# Altium schematic sheet 11-POWER-P12V.SchDoc(11-POWER-P12V)
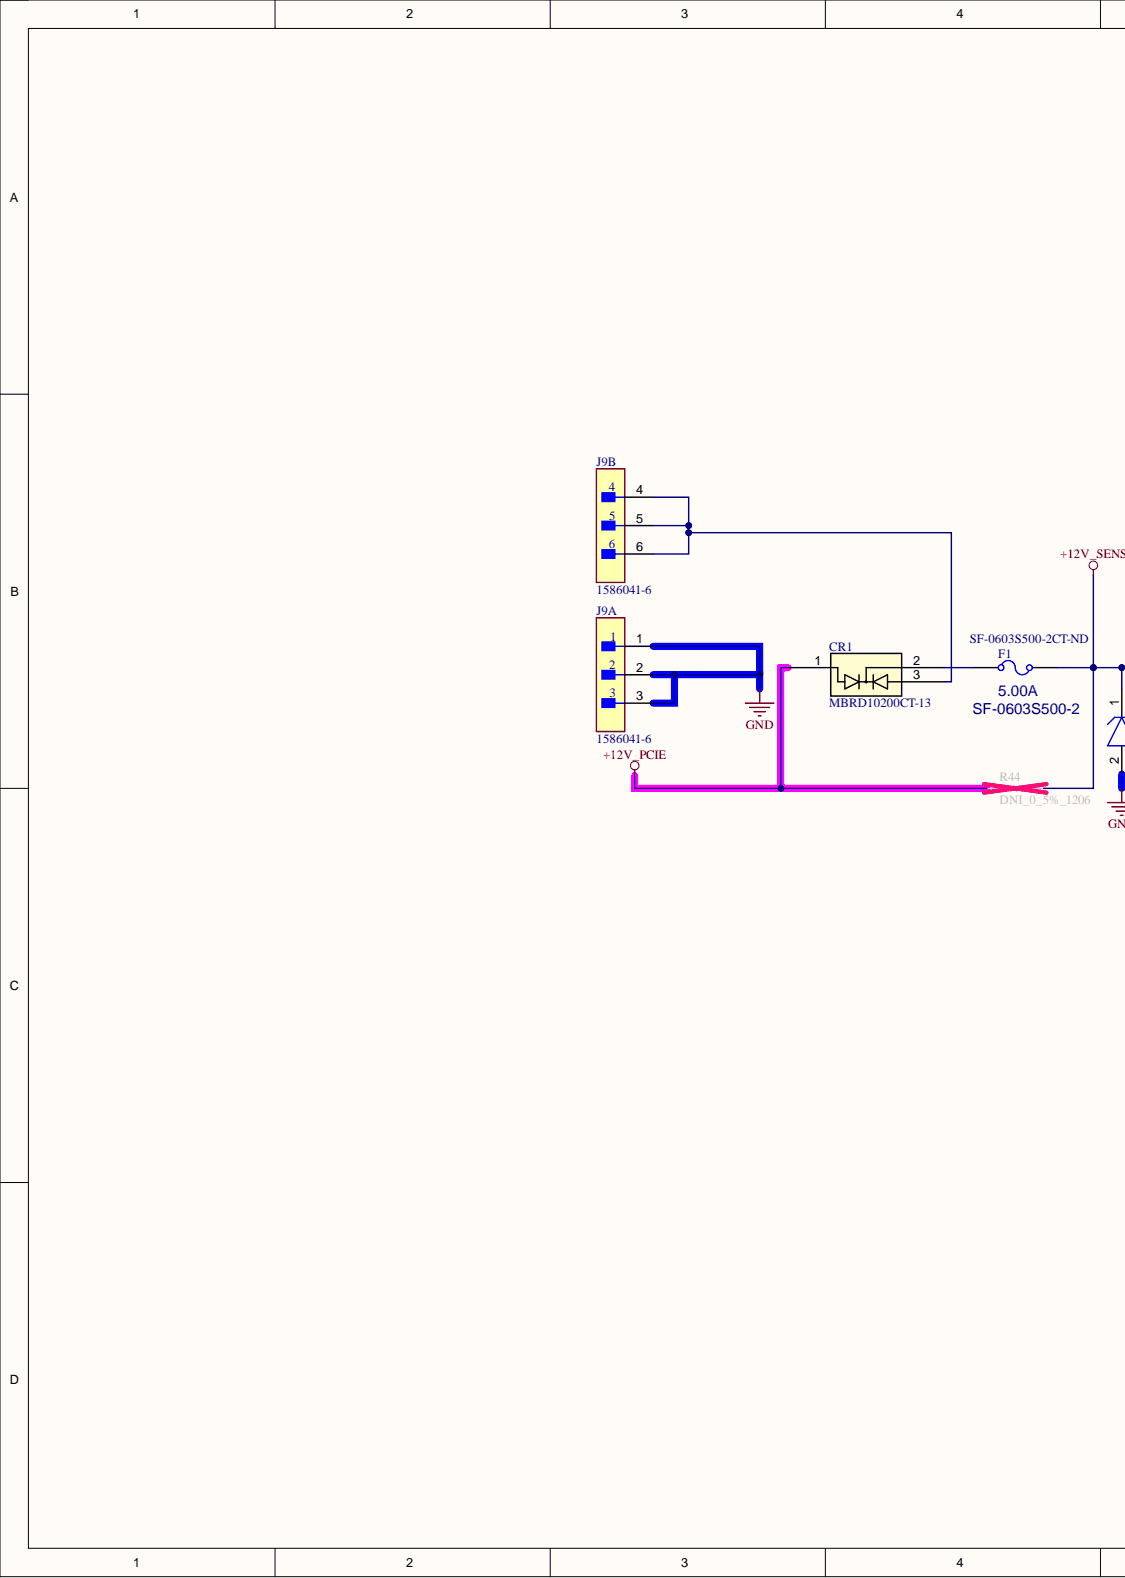
[diagram p0: 11-POWER-P12V.SchDoc, left]
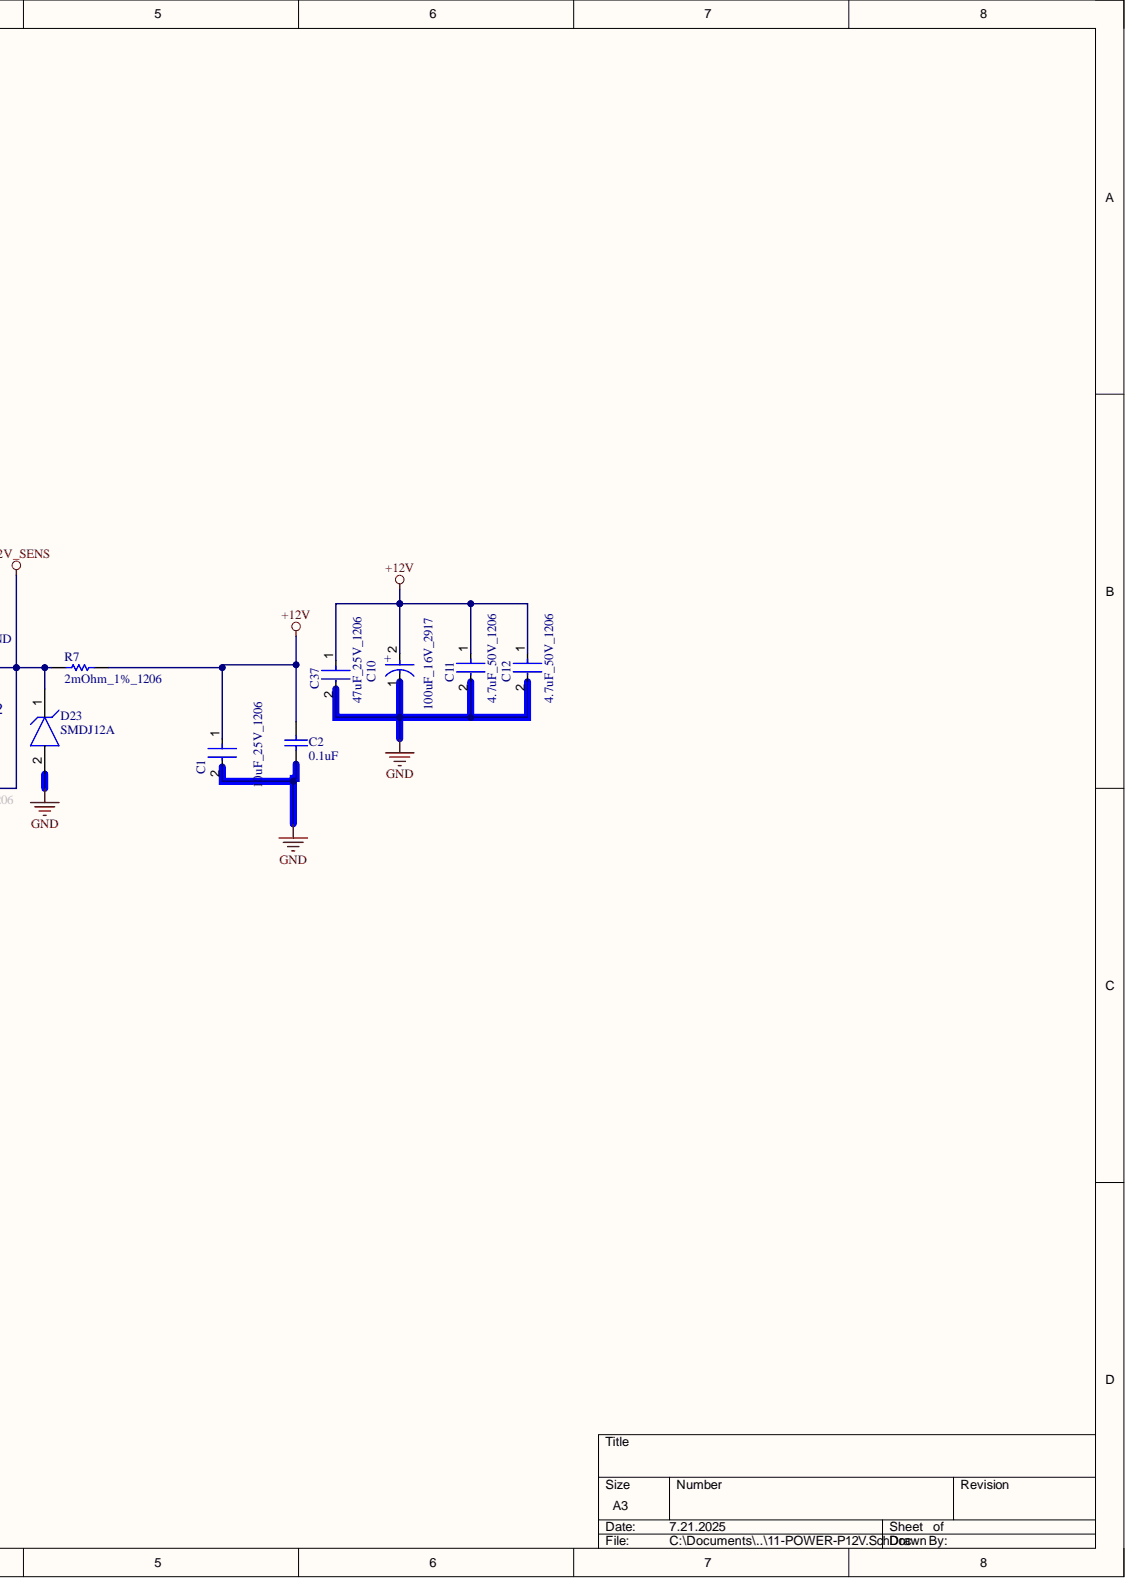
[diagram p1: 11-POWER-P12V.SchDoc, right]

## Parts
C1  10uF_25V_1206  [FP-MK316L-MFG]
C2  0.1uF  [CAPC1608X87X45ML20T05]
C10  100uF_16V_2917  [FP-T495D107K016ATE125-MFG]
C11  4.7uF_50V_1206  [FP-GRM31C-0_2-e0_3_0_8-IPC_C]
C12  4.7uF_50V_1206  [FP-GRM31C-0_2-e0_3_0_8-IPC_C]
C37  47uF_25V_1206  [FP-C3216-160-0_2-MFG]
CR1  MBRD10200CT-13  [TO252(DPAK)_DIO]
D23  SMDJ12A  [FP-DO-214AB_SMC_J-Bend-MFG]
F1  value 5.00A  MFG PART NUM: SF-0603S500-2  Supplier Part Number 1: SF-0603S500-2CT-ND  [FUSM1608X60N]  (hidden comment: FUSE_0603_5.00A)
J9  1586041-6  [FP-1586041-6-MFG]  (parts A,B)
R7  2mOhm_1%_1206  [RESC3216X89X64NL25T25]
R44  DNI_0_5%_1206  [RESC3116X65X50ML20T20]  (no BOM)

## Nets
+12V: C1.1 C2.2 C10.2 C11.1 C12.1 C37.1 R7.2
+12V_PCIE: CR1.1 R44.1
+12V_SENS: D23.1 F1.2 R7.1 R44.2
GND: C1.2 C2.1 C10.1 C11.2 C12.2 C37.2 D23.2 J9.1 J9.2 J9.3
NetCR1_2: CR1.2 F1.1
NetCR1_3: CR1.3 J9.4 J9.5 J9.6

## Library data of the parts
C1 = CMP-2000-07261-2: CAP CER 10UF 25V X7R 1206; Tolerance: 10%; Capacitance: 10uF; Case Code (Imperial): 1206; Case Code (Metric): 3216; Case/Package: 1206; Component Kind: Standard; Construction: Flat; Dielectric: X7R; Height: 1.6mm; Length: 0.126inch; Library Name: Altium Content Vault; Max Operating Temperature: 125°C; Min Operating Temperature: -55°C; Mount: Surface Mount; Number of Pins: 2; Package Quantity: 1; Packaging: Tape and Reel; Resistor Type: Ceramic; RoHS Compliant: Yes; Series: M; Termination: SMD/SMT; Thickness: 0.071inch; Voltage Rating: 25V; Voltage Rating (DC): 25V; Weight: 0.000571oz; Width: 1.6mm
C2 = CMP-2006-00003-1: C0603X104K5RACAUTO; Manufacturer: Kemet; Component Kind: Standard; Library Name: Altium Content Vault; Part Number: C0603X104K5RACAUTO
C10 = CMP-2000-07207-2: CAP TANT 100UF 10% 16V 2917; Dielectric Material: Tantalum; Dissipation Factor: 8%; ESR (Equivalent Series Resistance): 125mR; Life (Hours): 2000Hour; Polarity: Polar; Capacitance: 100uF; Case Code (Imperial): 2917; Case Code (Metric): 7343; Case/Package: 2917; Component Kind: Standard; Construction: Flat; Depth: 4.3mm; Failure Rate: 0.001%; Features: General Purpose; Height: 2.8mm; Height - Seated (Max): 0.122inch; Length: 7.3mm; Library Name: Altium Content Vault; Max Operating Temperature: 125°C; Min Operating Temperature: -55°C; Mount: Surface Mount; Number of Pins: 2; Package Quantity: 1; Packaging: Tape and Reel; Radiation Hardening: No; REACH SVHC: No SVHC; RoHS Compliant: Yes; Series: T495; Termination: SMD/SMT; Tolerance: 10%; Voltage: 16V; Voltage Rating: 16V; Voltage Rating (DC): 16V; Weight: 0.023634oz; Width: 0.169inch
C11 C12 = CMP-1037-04923-3: Chip Multilayer Ceramic Capacitors for General Purpose, 1206, 4.7uF, X7R, 15%, 10%, 50V; Capacitance: 4.7uF; Case Code (Imperial): 1206; Case Code (Metric): 3216; Case/Package: 1206; Component Kind: Standard; Depth: 1.6mm; Dielectric: X7R; Height: 1.6mm; Lead Free: Lead Free; Length: 3.2mm; Library Name: Altium Content Vault; Material: Ceramic; Max Operating Temperature: 125°C; Min Operating Temperature: -55°C; Mount: Surface Mount; Package Quantity: 2000; Packaging: Tape and Reel; Radiation Hardening: No; REACH SVHC: No SVHC; Termination: SMD/SMT; Thickness: 0.071inch; Tolerance: 10%; Voltage: 50V; Voltage Rating: 50V; Voltage Rating (DC): 50V; Width: 0.063inch
C37 = CMP-2000-05397-3: Multilayer Ceramic Capacitors 47uF ±20% 25V X5R SMD 1206; Capacitance: 47uF; Case Code (Imperial): 1206; Case Code (Metric): 3216; Case/Package: 1206; Component Kind: Standard; Depth: 1.6mm; Dielectric: X5R; Dielectric Material: Ceramic; Height: 1.6mm; Lead Free: Lead Free; Length: 0.126inch; Library Name: Altium Content Vault; Max Operating Temperature: 85°C; Min Operating Temperature: -55°C; Mount: Surface Mount; Package Quantity: 1; Packaging: Tape and Reel; REACH SVHC: No SVHC; Resistor Type: Ceramic; RoHS Compliant: Yes; Series: C; Termination: SMD/SMT; Thickness: 0.071inch; Tolerance: 20%; Voltage: 25V; Voltage Rating: 25V; Voltage Rating (DC): 25V; Weight: 0.000571oz; Width: 0.063inch
CR1 = MBRD10200CT-13: No Description Available; Mfr_Name: Diodes Inc; Type: Diodes - Rectifiers, Arrays; Component Kind: Standard; Library Name: MBRD10200CT-13.SchLib; RefDes: RefDes
D23 = CMP-08607-000111-1: TVS DIODE 12V 19.9V DO214AB; Breakdown Voltage: 13.3V; Diode Type: ZENER; Direction: Unidirectional; Leakage Current: 2uA; Max Breakdown Voltage: 14.7V; Max Power Dissipation: 6.5W; Max Surge Current: 150.8A; Number of Channels: 1; Peak Pulse Power: 3kW; Power Line Protection: No; Test Current: 1mA; Case/Package: DO-214AB; Clamping Voltage: 19.9V; Clamping Voltage-Max: 19.9V; Component Kind: Standard; Lead Free: Lead Free; Library Name: Altium Content Vault; Max Operating Temperature: 150°C; Min Breakdown Voltage: 13.3V; Min Operating Temperature: -55°C; Mount: Surface Mount; Number of Elements: 1; Number of Pins: 2; Number of Unidirectional Channels: 1; Operating Supply Voltage: 12V; Package Quantity: 3000; Packaging: Tape and Reel; Peak Pulse Current: 150.8A; Polarity: Unidirectional; Power Dissipation: 3kW; REACH SVHC: No SVHC; Resistor Type: Zener; Reverse Breakdown Voltage: 13.3V; Reverse Standoff Voltage: 12V; RoHS Compliant: Yes; Series: SMDJ; Termination: SMD/SMT
F1 = FUSE_0603_2.00A: FUSE SLOW 5.00A 32V M 0603; VALENTIUM PART NUM: <VALENTIUM>; DESC: FUSE SLOW 1.25A 32V M 0603; MAXTEMP: 105C; MFG NAME: BOURNS; MINTEMP: -40C; OTHER: UL E141069; P1: SLOW BLO; CATEGORY: FUSE; Component Kind: Standard; DATE: 20 OCT 15; Library Name: Passives.IntLib; MODIFY DATE: 9/4/2013; P2: 32V; P3: M; SIZE: 0603; Supplier 1: Digi-Key
J9 = CMP-03369-000060-1: CONN HEADER R/A 6POS 4.2MM; Manufacturer: TE; Conn Gender: Male; Conn Orientation: Right Angle; Current Rating: 9A; Number Of Contacts: 6; Standoff Height: 0.4mm; Voltage Rating: 600V; Automotive: No; Category: Conn; Component Kind: Standard; ComponentLink2Description: Datasheet; ComponentLink2URL: https://www.te.com/commerce/DocumentDelivery/DDEController?Action=showdoc&DocId=Specification+Or+Standard%7F108-2112%7FD2%7Fpdf%7FEnglish%7FENG_SS_108-2112_D2.pdf%7F1586041-6; Contact Material: Tin,Nickel; Device Class L1: Connectors; Device Class L2: Headers and Wire Housings; Device Class L3: unset; Digikey Description: CONN HEADER R/A 6POS 4.2MM; Footprint Url: https://www.te.com/commerce/DocumentDelivery/DDEController?Action=showdoc&DocId=Customer+Drawing%7F1586041%7FB5%7Fpdf%7FEnglish%7FENG_CD_1586041_B5.pdf%7F1586041-6; Height: 11.95mm; Lead Free: Yes; Library Name: Altium Content Vault; Manufacturer Part Number: 1586041-6; Mouser Description: Conn Power HDR 6 POS 4.2mm Solder RA Thru-Hole 6 Terminal 1 Port Tray; Number Of Rows: 2; Octopart: https://octopart.com/1586041-6-te+connectivity-46514050; Package: 1586041-6; Pitch: 4.2mm; RoHS: TRUE; Temperature: -40°C to +105°C; Temperature Range High: +105°C; Temperature Range Low: -40°C
R7 = CMP-2003-04334-1: Manufacturer: Vishay Dale; Part Number: WSL12062L000FEA18; Component Kind: Standard; Library Name: Altium Content Vault
R44 = CMP-2003-04873-1: Manufacturer: Vishay Dale; Part Number: CRCW12060000Z0EAHP; Library Name: Altium Content Vault
